# Altium schematic sheet Top.SchDoc(U_Top)
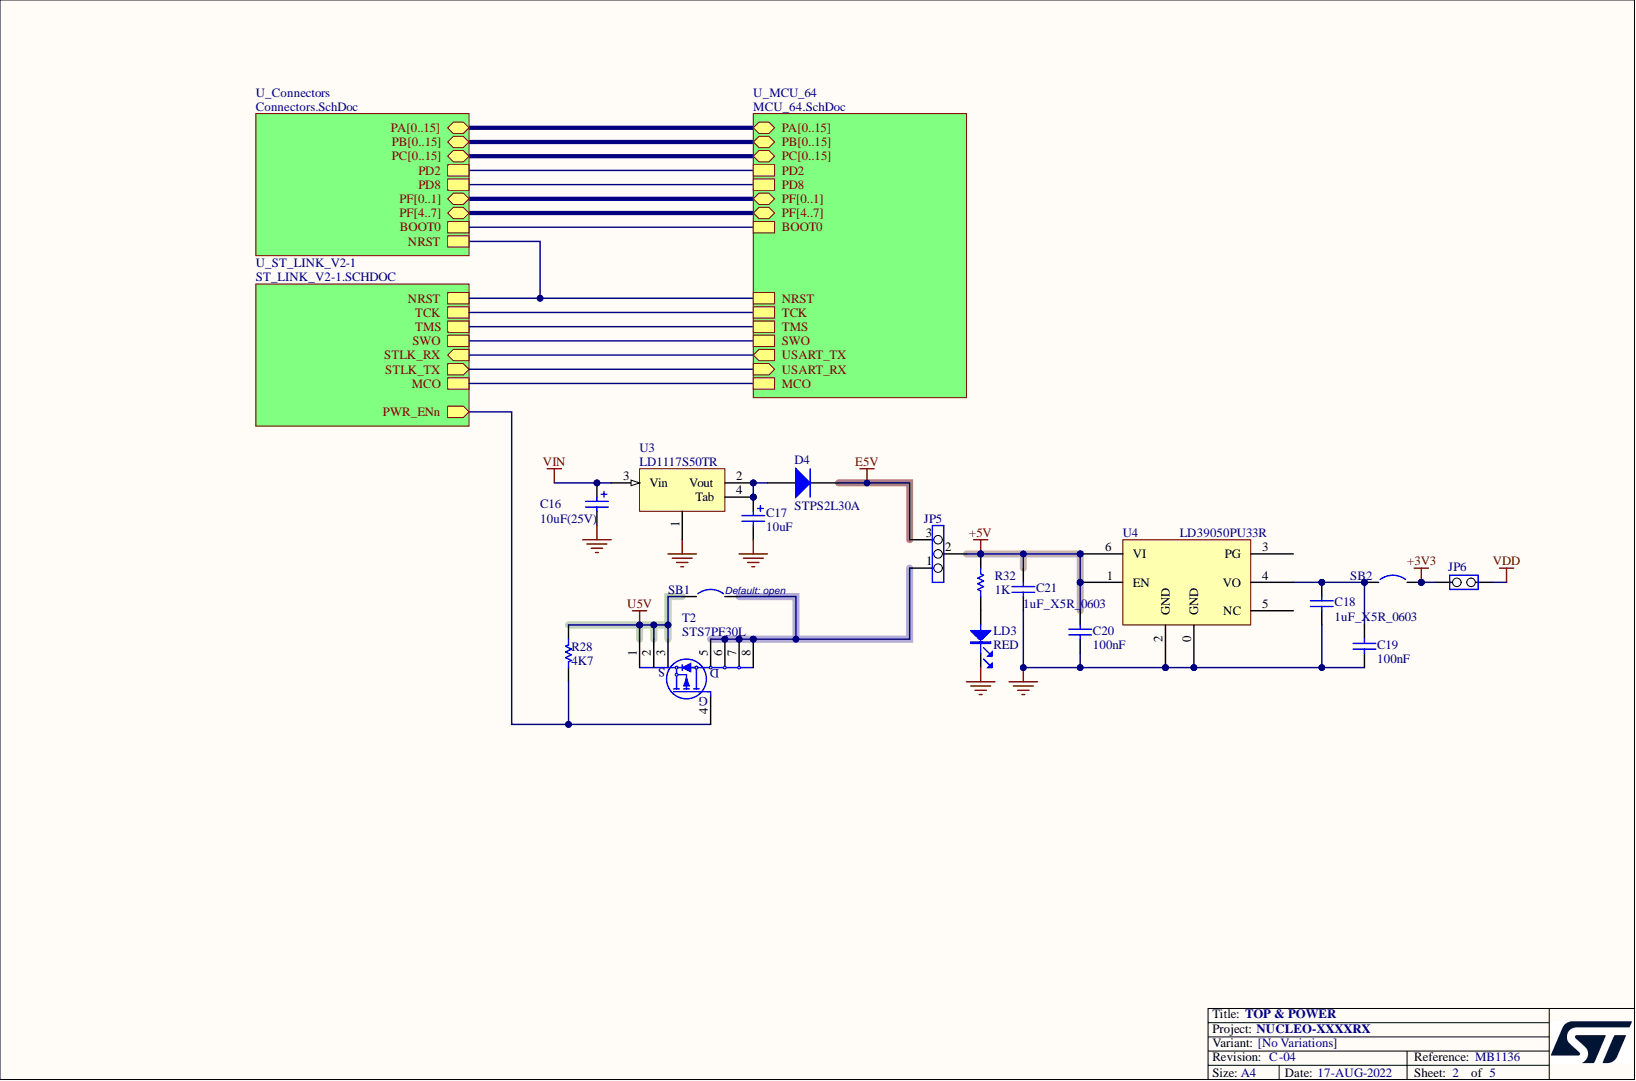
[diagram p0: Top.SchDoc]

## Parts
C16  10uF(25V)  [TAN-B]
C17  10uF  [TAN-A]
C18  1uF_X5R_0603  [0603C]
C19  100nF  [0603C]
C20  100nF  [0603C]
C21  1uF_X5R_0603  [0603C]
D4  STPS2L30A  [SMA]
JP5  (no value)  [SIP3]
JP6  (no value)  [SIP2/2.54]
LD3  RED  [0603D]
R28  4K7  [0603R]
R32  1K  [0603R]
SB1  Circuit Breaker  [JP2_SMD]  (value not drawn)
SB2  Circuit Breaker  [JP2_SMD]  (value not drawn)
T2  STS7PF30L  [SO8]
U3  LD1117S50TR  [SOT-223]
U4  LD39050PU33R  [DFN6(3X3)]

## Nets
+3V3: JP6.1 SB2.2
+5V: C20.2 C21.1 JP5.2 R32.2 U4.1(EN) U4.6(VI)
E5V: D4.2 JP5.3
GND: C16.2 C17.2 C18.2 C19.1 C20.1 C21.2 LD3.2 U3.1 U4.0(GND) U4.2(GND)
U5V: R28.1 SB1.1 T2.1 T2.2 T2.3
VDD: JP6.2
VIN: C16.1 U3.3(Vin)
NetC17_1: C17.1 D4.1 U3.2(Vout) U3.4(Tab)
NetC18_1: C18.1 C19.2 SB2.1 U4.4(VO)
NetJP5_1: JP5.1 SB1.2 T2.5 T2.6 T2.7 T2.8
NetLD3_1: LD3.1 R32.1
NetR28_2: R28.2 T2.4
NetU4_3: U4.3(PG)
NetU4_5: U4.5(NC)
BOOT0:
MCO:
NRST:
PA0:
PA1:
PA2:
PA3:
PA4:
PA5:
PA6:
PA7:
PA8:
PA9:
PA10:
PA11:
PA12:
PA13:
PA14:
PA15:
PB0:
PB1:
PB2:
PB3:
PB4:
PB5:
PB6:
PB7:
PB8:
PB9:
PB10:
PB11:
PB12:
PB13:
PB14:
PB15:
PC0:
PC1:
PC2:
PC3:
PC4:
PC5:
PC6:
PC7:
PC8:
PC9:
PC10:
PC11:
PC12:
PC13:
PC14:
PC15:
PD2:
PD8:
PF0:
PF1:
PF4:
PF5:
PF6:
PF7:
PF[0..1]:
PF[4..7]:
STLK_RX:
STLK_TX:
SWO:
TCK:
TMS:

## Library data of the parts
C16 C17 = Cap Pol1: Polarized Capacitor (Radial); Component Kind: Standard; Library Name: Miscellaneous Devices.IntLib; Published: 8-Jun-2000; Revision: July-2002: Re-released for DXP Platform.; Signal Integrity: C200M; Simulation: CAP; Value: 10uF; Publisher: Altium Limited
C18 C21 = CAP SMD 0603  X5R 1µF 25V 10%: 0603  X5R 1µF; Rated voltage: 25V; Tolerance: 10%; Component Kind: Standard; Library Name: MB963_1.SCHLIB; Order code Farnell: 1288202RL; PCB3D: 0603_0_0000_R; Signal Integrity: Capacitor; Simulation: Capacitor; Technology: X5R; Temperature Max: +125°C; Temperature Min: -55°C; Value: 1µF
C19 C20 = Capacitor_ST: Capacitor 0402 / 0603 / 0805; Component Kind: Standard; Library Name: MCD_TOOLS.SchLib; Revision: July-2002: Re-released for DXP Platform.; Signal Integrity: Cap; Simulation: CAP; Value: 100nF
D4 = STPS2L30A: Manuf_1: STM; Manuf_Part_1: BAT60JFILM; ECCN_US/EU: EAR99/NEC; Provided: ST; Component Kind: Standard; Description: STPS2L30A; Distrib: ST; Library Name: Nucleo_64.SCHLIB; Order: BAT60JFILM; Revision: July-2002: Re-released for DXP Platform.
JP5 = Jumper3: Component Kind: Standard; Library Name: STR910_SCHLIB.SCHLIB
JP6 = JP2: Component Kind: Standard
LD3 = PHOTO: Component Kind: Standard; Library Name: MB963_1.SCHLIB
R28 = Res1: Resistor; Value: 1K; Component Kind: Standard; Published: 8-Jun-2000; Revision: July-2002: Re-released for DXP Platform.; Publisher: Altium Limited
R32 = Resistor_ST: Resistor 0402 / 0603 / 0805; Value: 10K; Component Kind: Standard; Library Name: MCD_TOOLS.SchLib
SB1 SB2 = Circuit Breaker: Solder: NO; Component Kind: Standard; Description: Circuit Breaker; Library Name: MCD_TOOLS.SCHLIB; Published: 24-Mar-1999; Revision: July-2002: Re-released for DXP Platform.; Publisher: Altium Limited
U3 = LD1117: Component Kind: Standard; Library Name: mb1045.SCHLIB
U4 = LD39050P: Component Kind: Standard; Library Name: Nucleo_64.SCHLIB
T2 = STS7PF30L: Component Kind: Standard; Library Name: Nucleo_64.SCHLIB
